# Altium schematic sheet Top.SchDoc
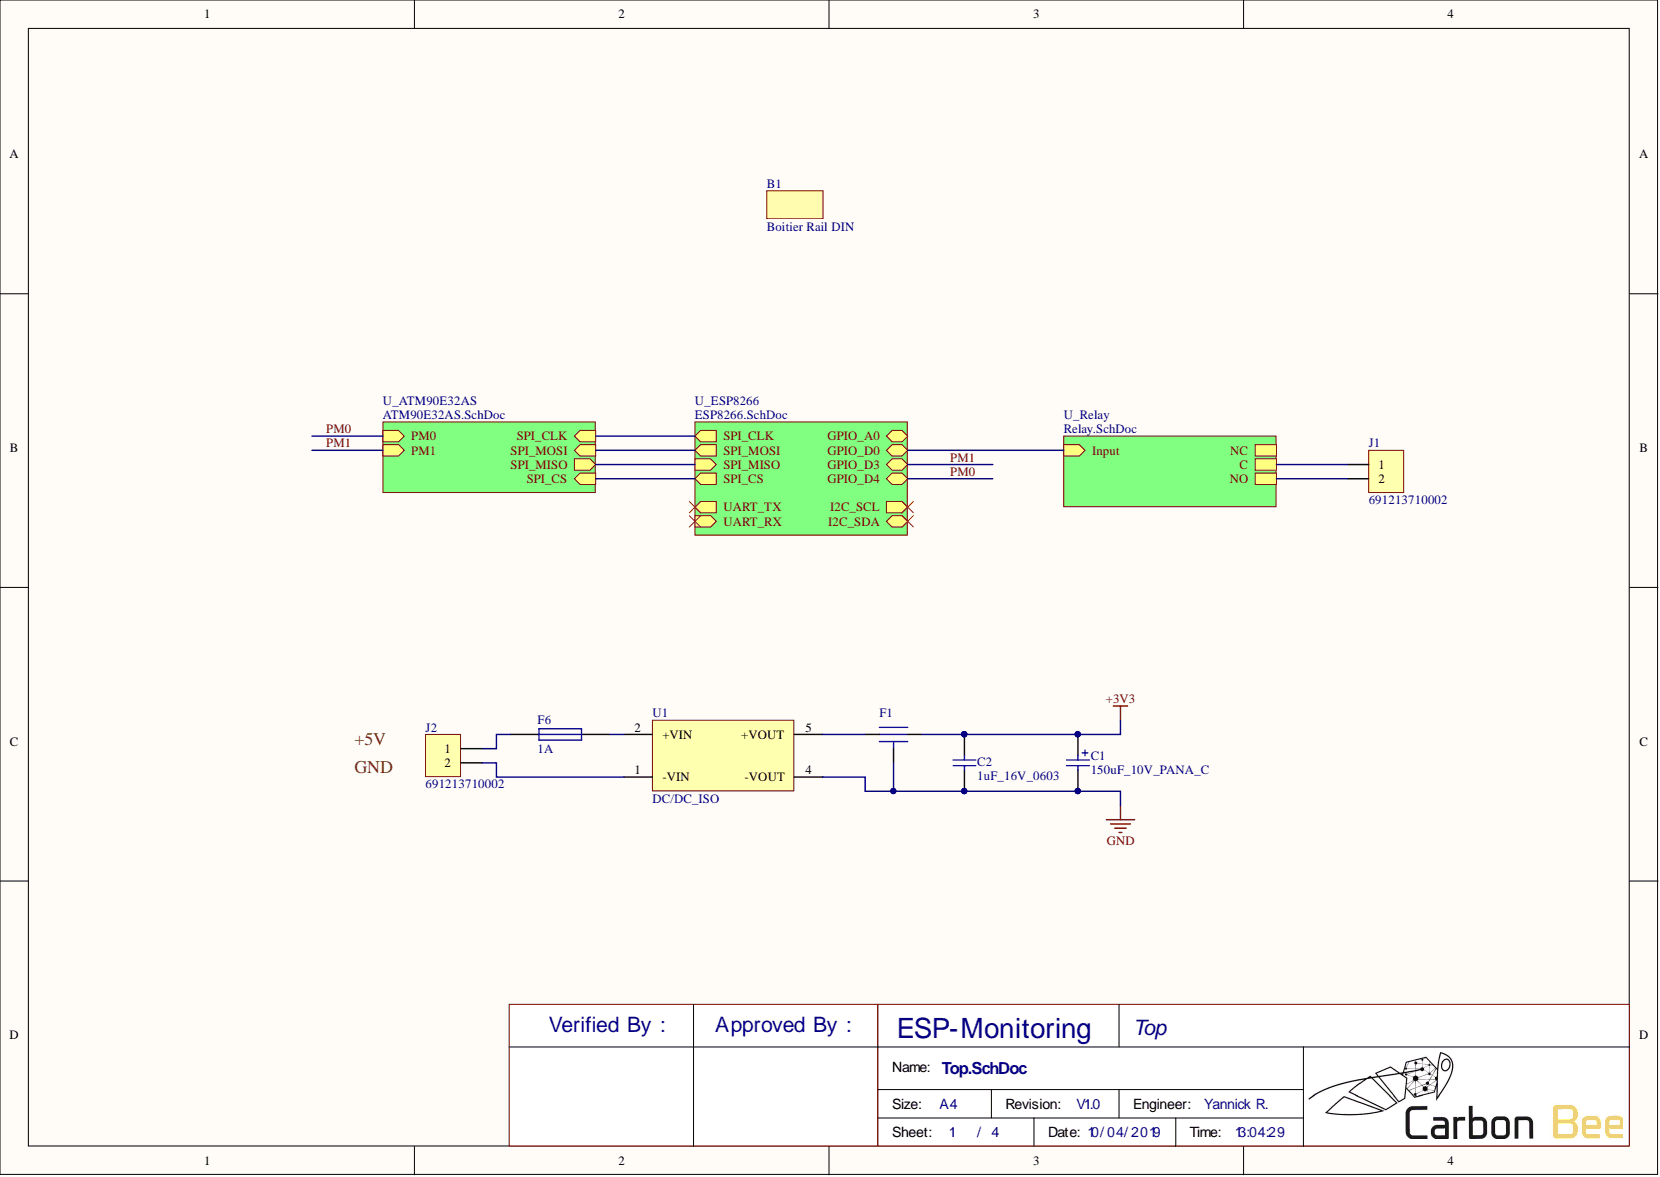
[diagram p0: Top.SchDoc]

## Parts
B1  Boitier Rail DIN
C1  150uF_10V_PANA_C  [CAP_PANA_C]
C2  1uF_16V_0603  [C0603]
F1  EMI  [EMIFIL_0603]  (value not drawn)
F6  1A  [R0603]
J1  691213710002  [691213710002]
J2  691213710002  [691213710002]
U1  DC/DC_ISO  [R1SE]

## Nets
+3V3: C1.1 C2.2 F1.1
GND: C1.2 C2.1 F1.GND U1.4(-VOUT)
NetF1_2: F1.2 U1.5(+VOUT)
NetF6_1: F6.1 U1.2(+VIN)
NetF6_2: F6.2 J2.1
NetJ1_1: J1.1
NetJ1_2: J1.2
NetJ2_2: J2.2 U1.1(-VIN)
GPIO_A0:
GPIO_D0:
I2C_SCL:
I2C_SDA:
NC:
PM0:
PM1:
SPI_CLK:
SPI_CS:
SPI_MISO:
SPI_MOSI:
UART_RX:
UART_TX:

## Library data of the parts
B1 = Component: Part of schematic; Component Kind: Standard; Library Name: Common.SchLib
C1 = Cap Pol: Polarized Capacitor (Radial); MPN: EEEFT1A151AR; MF: PANASONIC ELECTRONIC COMPONENTS; Component Kind: Standard; Library Name: Common.SchLib; Signal Integrity: Cap Pol; Simulation: CAP; Supplier 1: Farnell; Supplier Part Number 1: 2065960; Supplier Pricing 1: 0,408€
C2 = Cap: Capacitor; MPN: 885012106017; MF: WURTH ELEKTRONIK; Component Kind: Standard; Library Name: Common.SchLib; Signal Integrity: Cap; Simulation: CAP; Supplier 1: Farnell; Supplier Part Number 1: 2533943; Supplier Pricing 1: 0,0886€
F1 = EMIFIL_0603: FILTER CAP 0.1UF 2A 16V 0603; MPN: NFM18PC104R1C3D; MF: MURATA; Component Kind: Standard; Library Name: Common.SchLib; Supplier 1: Farnell; Supplier Part Number 1: 1686508; Supplier Pricing 1: 0,083 €
F6 = Fuse 1: Fuse; MPN: MCCFB0603TFF/1; MF: MULTICOMP; Component Kind: Standard; Library Name: Miscellaneous Devices.IntLib; Simulation: FUSE; Supplier 1: Farnell; Supplier Part Number 1: 2849996; Supplier Pricing 1: 0,101 €
J1 J2 = 691213710002: WR-TBL Horizontal Entry Modular with Rising Cage Clamp,Serie 213 - 5.00 mm; Manufacturer: Würth Elektronik eiSos GmbH; ComponentLink1 Description: Manufacturer; ComponentLink2 Description: Datasheet; NoPins: 2; Component Kind: Standard; ComponentLink1URL: http://www.we-online.com; ComponentLink2URL: http://katalog.we-online.de/em/datasheet/6912137100xx.pdf; Library Name: WE_WR-TBL_Rising Cage System_5.00 mm_rev15d.IntLib; partNo: 691213710002; Created by: Karrer Zheng; Created Date: 25 Novenber 2013
U1 = DC/DC_ISO: CONVERTER DC/DC ISOLATED; MPN: ISH0503A; MF: XP POWER; Component Kind: Standard; Library Name: Common.SchLib; Supplier 1: Farnell; Supplier Part Number 1: 2484118; Supplier Pricing 1: 6,95 €
